# Altium schematic sheet Sheet1.SchDoc(Sheet1)
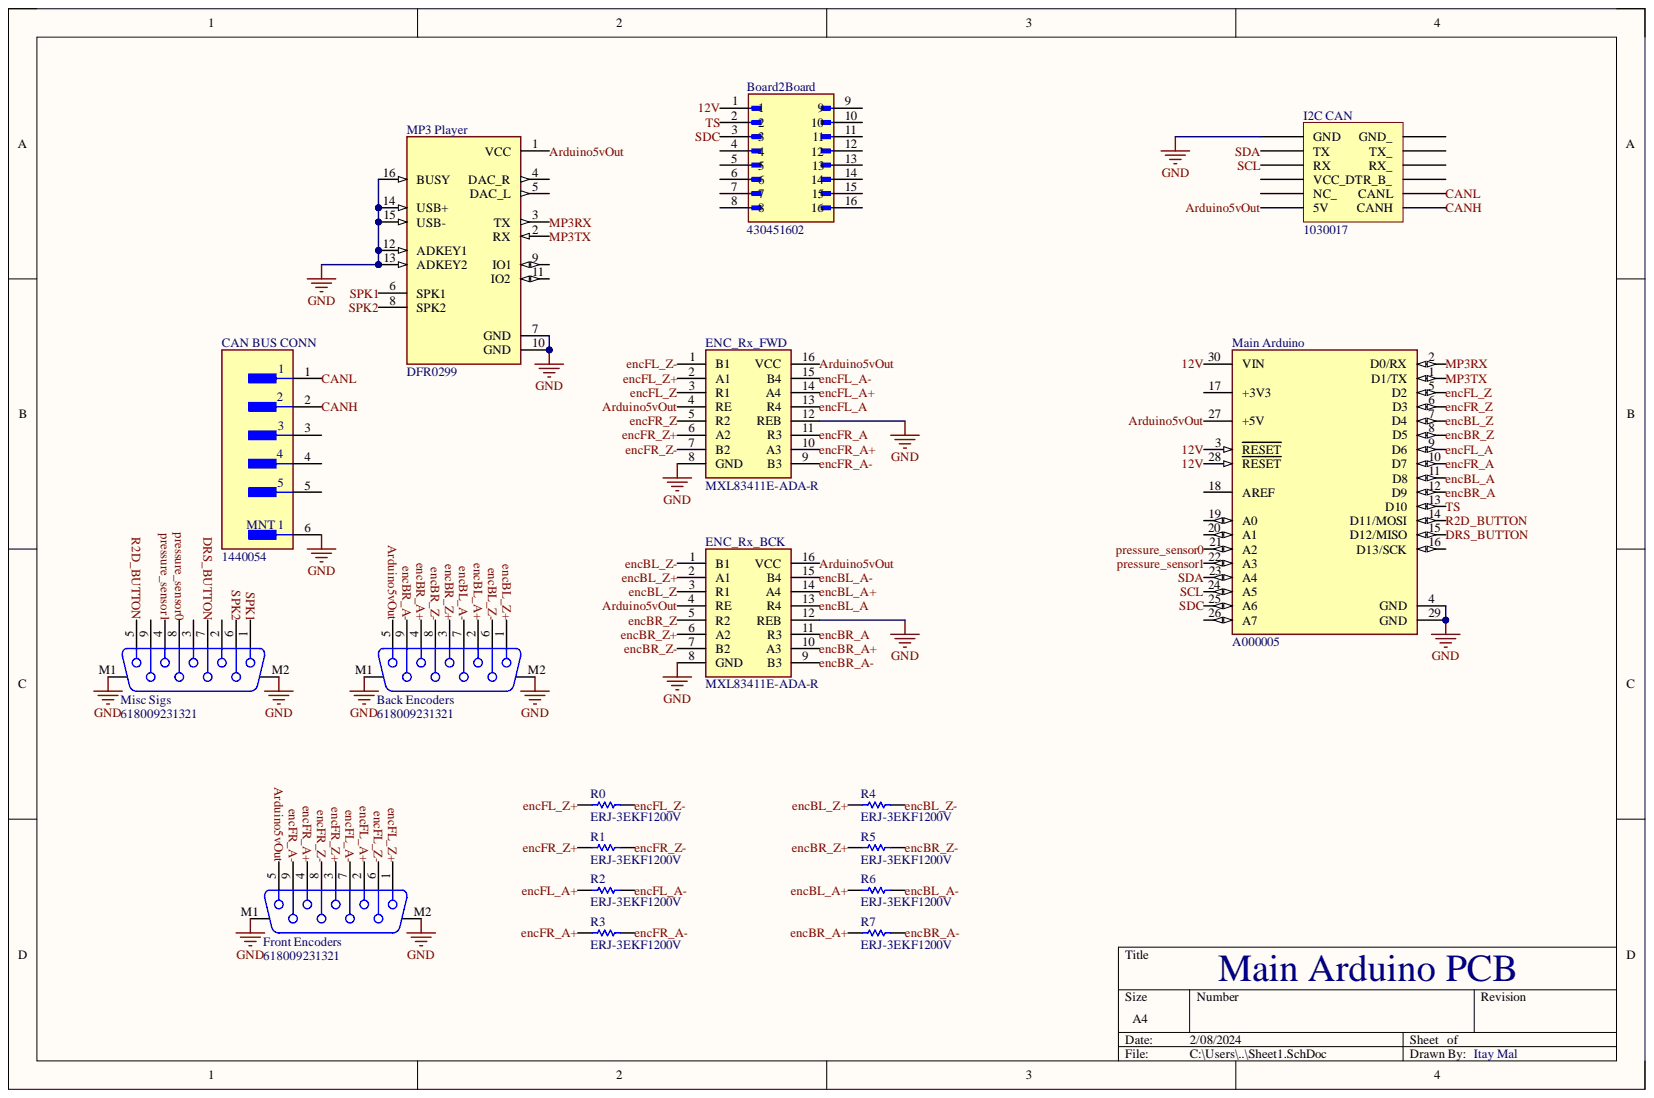
[diagram p0: Sheet1.SchDoc]

## Parts
Back_Encoders  618009231321  [618009231321]
Board2Board  430451602  [MOLEX_430451602]
CAN_BUS_CONN  1440054  [FP-1440054-MFG]
ENC_Rx_BCK  MXL83411E-ADA-R  [SOIC127P600X175-16N]
ENC_Rx_FWD  MXL83411E-ADA-R  [SOIC127P600X175-16N]
Front_Encoders  618009231321  [618009231321]
I2C_CAN  I2C_CAN  [I2C_CAN-Footprint-1]
Main_Arduino  A000005  Operating Supply Voltage: 12V  [FP-A000005-MFG]
Misc_Sigs  618009231321  [618009231321]
MP3_Player  DFR0299  [MODULE_DFR0299]
R0  ERJ-3EKF1200V  [RESC1609X55X30ML15T15]
R1  ERJ-3EKF1200V  [RESC1609X55X30ML15T15]
R2  ERJ-3EKF1200V  [RESC1609X55X30ML15T15]
R3  ERJ-3EKF1200V  [RESC1609X55X30ML15T15]
R4  ERJ-3EKF1200V  [RESC1609X55X30ML15T15]
R5  ERJ-3EKF1200V  [RESC1609X55X30ML15T15]
R6  ERJ-3EKF1200V  [RESC1609X55X30ML15T15]
R7  ERJ-3EKF1200V  [RESC1609X55X30ML15T15]

## Nets
GND: Back_Encoders.M1 Back_Encoders.M2 CAN_BUS_CONN.6 ENC_Rx_BCK.8(GND) ENC_Rx_BCK.12(REB) ENC_Rx_FWD.8(GND) ENC_Rx_FWD.12(REB) Front_Encoders.M1 Front_Encoders.M2 I2C_CAN.GND Main_Arduino.4(GND) Main_Arduino.29(GND) Misc_Sigs.M1 Misc_Sigs.M2 MP3_Player.7(GND) MP3_Player.10(GND) MP3_Player.12(ADKEY1) MP3_Player.13(ADKEY2) MP3_Player.14(USB+) MP3_Player.15(USB-) MP3_Player.16(BUSY)
NetI2C_CAN_DTR_B_: I2C_CAN.DTR_B_
NetI2C_CAN_GND_: I2C_CAN.GND_
NetI2C_CAN_NC_: I2C_CAN.NC_
NetI2C_CAN_RX_: I2C_CAN.RX_
NetI2C_CAN_TX_: I2C_CAN.TX_
NetI2C_CAN_VCC_: I2C_CAN.VCC_
12V: Board2Board.1 Main_Arduino.3(RESET) Main_Arduino.28(RESET) Main_Arduino.30(VIN)
Arduino5vOut: Back_Encoders.5 ENC_Rx_BCK.4(RE) ENC_Rx_BCK.16(VCC) ENC_Rx_FWD.4(RE) ENC_Rx_FWD.16(VCC) Front_Encoders.5 I2C_CAN.5V Main_Arduino.27(+5V) MP3_Player.1(VCC)
CANH: CAN_BUS_CONN.2 I2C_CAN.CANH
CANL: CAN_BUS_CONN.1 I2C_CAN.CANL
DRS_BUTTON: Main_Arduino.15(D12/MISO) Misc_Sigs.7
encBL_A: ENC_Rx_BCK.13(R4) Main_Arduino.11(D8)
encBL_A+: Back_Encoders.2 ENC_Rx_BCK.14(A4) R6.1
encBL_A-: Back_Encoders.7 ENC_Rx_BCK.15(B4) R6.2
encBL_Z: ENC_Rx_BCK.3(R1) Main_Arduino.7(D4)
encBL_Z+: Back_Encoders.1 ENC_Rx_BCK.2(A1) R4.1
encBL_Z-: Back_Encoders.6 ENC_Rx_BCK.1(B1) R4.2
encBR_A: ENC_Rx_BCK.11(R3) Main_Arduino.12(D9)
encBR_A+: Back_Encoders.4 ENC_Rx_BCK.10(A3) R7.1
encBR_A-: Back_Encoders.9 ENC_Rx_BCK.9(B3) R7.2
encBR_Z: ENC_Rx_BCK.5(R2) Main_Arduino.8(D5)
encBR_Z+: Back_Encoders.3 ENC_Rx_BCK.6(A2) R5.1
encBR_Z-: Back_Encoders.8 ENC_Rx_BCK.7(B2) R5.2
encFL_A: ENC_Rx_FWD.13(R4) Main_Arduino.9(D6)
encFL_A+: ENC_Rx_FWD.14(A4) Front_Encoders.2 R2.1
encFL_A-: ENC_Rx_FWD.15(B4) Front_Encoders.7 R2.2
encFL_Z: ENC_Rx_FWD.3(R1) Main_Arduino.5(D2)
encFL_Z+: ENC_Rx_FWD.2(A1) Front_Encoders.1 R0.1
encFL_Z-: ENC_Rx_FWD.1(B1) Front_Encoders.6 R0.2
encFR_A: ENC_Rx_FWD.11(R3) Main_Arduino.10(D7)
encFR_A+: ENC_Rx_FWD.10(A3) Front_Encoders.4 R3.1
encFR_A-: ENC_Rx_FWD.9(B3) Front_Encoders.9 R3.2
encFR_Z: ENC_Rx_FWD.5(R2) Main_Arduino.6(D3)
encFR_Z+: ENC_Rx_FWD.6(A2) Front_Encoders.3 R1.1
encFR_Z-: ENC_Rx_FWD.7(B2) Front_Encoders.8 R1.2
MP3RX: Main_Arduino.2(D0/RX) MP3_Player.3(TX)
MP3TX: Main_Arduino.1(D1/TX) MP3_Player.2(RX)
pressure_sensor0: Main_Arduino.21(A2) Misc_Sigs.8
pressure_sensor1: Main_Arduino.22(A3) Misc_Sigs.4
R2D_BUTTON: Main_Arduino.14(D11/MOSI) Misc_Sigs.5
SCL: I2C_CAN.RX Main_Arduino.24(A5)
SDA: I2C_CAN.TX Main_Arduino.23(A4)
SDC: Board2Board.3 Main_Arduino.25(A6)
SPK1: Misc_Sigs.1 MP3_Player.6(SPK1)
SPK2: Misc_Sigs.6 MP3_Player.8(SPK2)
TS: Board2Board.2 Main_Arduino.13(D10)

Not connected: Board2Board 4 5 6 7 8 9 10 11 12 13 14 15 16; CAN_BUS_CONN 3 4 5; Main_Arduino 16(D13/SCK) 17(+3V3) 18(AREF) 19(A0) 20(A1) 26(A7); Misc_Sigs 2 3 9; MP3_Player 4(DAC_R) 5(DAC_L) 9(IO1) 11(IO2)

## Library data of the parts
Back_Encoders Front_Encoders Misc_Sigs = CMP-000000-1: D Type Connector, 9 Contact(s), Female, 0.109 inch Pitch, Solder Terminal, Locking, Plug; Manufacturer Series: 6180; Body Breadth: 0.492inch; Body Depth: 0.581inch; Body Length: 1.213inch; Contact Pattern: STAGGERED; Contact Resistance: 30mR; Contact Style: RND PIN-SKT; Dielectric Withstanding Voltage (AC): 1kV; Insulation Resistance: 5GR; Mating Contact Row Spacing: 0.112inch; Number of Contacts: 9; Number Of PCB Rows: 2; PCB Contact Row Spacing: 2.8448mm; Rated Current (Signal): 3A; Reference Standard: UL; Reliability: COMMERCIAL; Body/Shell Style: PLUG; Component Kind: Standard; Contact Finish - Mating: AU; Contact Gender: Female; Contact Material: Copper Alloy; Insulation Color: Black; Insulation Material: Polybutylene; Library Name: main arduino pcb; Mating Contact Pitch: 0.109inch; Max Operating Temperature: 85°C; Min Operating Temperature: -40°C; Mounting Option 1: LOCKING; Mounting Style: RIGHT ANGLE; Mounting Technology: Board; Number of Rows Loaded: 2; PCB Contact Pattern: STAGGERED; Reach SVHC Compliant: Yes; RoHS Compliant: Yes; Shell Finish: Nickel; Shell Material: Steel; Terminal Length: 0.125inch; Terminal Pitch: 2.7686mm; Termination: Solder
Board2Board = CMP-005-000000-2: Availability: Unavailable; Component Kind: Standard; Component Type: Standard; Design Item ID: 430451602; Library Name: main arduino pcb; MP: 430451602; Package: None; Pin Count: 16; Price: None; Source: 430451602.SchLib
CAN_BUS_CONN = CMP-00002-1: Circular Connector; Component Kind: Standard; Connector Type: Circular; Contact Finish - Mating: NOT SPECIFIED; Library Name: main arduino pcb; Reach SVHC Compliant: No; RoHS Compliant: Yes
ENC_Rx_BCK ENC_Rx_FWD = MXL83411E-ADA-R: Integrated Circuit; Manufacturer_Name: MaxLinear; Component Kind: Standard; Datasheet Link: https://assets.maxlinear.com/web/documents/mxl83411.pdf; Height: 1.75mm; Manufacturer_Part_Number: MXL83411E-ADA-R; Mouser Part Number: 701-MXL83411E-ADA-R; Mouser Price/Stock: https://www.mouser.co.uk/ProductDetail/MaxLinear/MXL83411E-ADA-R?qs=Z%252BL2brAPG1KMxbLdzofcfg%3D%3D
I2C_CAN = CMP-007-000000-2: Component Kind: Standard; Component Type: Standard; Design Item ID: I2C_CAN; Library Name: main arduino pcb; Pin Count: 12; Source: Schlib1.SchLib
Main_Arduino = CMP-189986-000002-1: ARDUINO NANO ATMEGA328 EVAL BRD; Core Architecture: AVR; Data Bus Width: 8b; Evaluation Kit: Yes; Interface: I2C; Number of Bits: 8; Component Kind: Standard; Height: 0.25inch; Length: 7inch; Library Name: Altium Content Vault; Package Quantity: 50; Packaging: Bulk; REACH SVHC: No SVHC; Series: AVR® ATmega; Weight: 0.358383oz; Width: 5inch
MP3_Player = CMP-003-000000-2: Dfplayer - a Mini Mp3 Player; Availability: Unavailable; Component Kind: Standard; Component Type: Standard; Design Item ID: DFR0299; Library Name: main arduino pcb; MP: DFR0299; Package: None; Pin Count: 16; Price: None; Source: DFR0299.SchLib
R0-R7 = CMP-2000-00213-2: Chip Resistor, 120 Ohm, +/- 1%, 0.1 W, -55 to 155 degC, 0603 (1608 Metric), RoHS, Tape and Reel; Manufacturer: Panasonic; Max Power Dissipation: 100mW; Resistance: 120Ohm; Temperature Coefficient: 100ppm/degreesC; Voltage Rating: 75V; Case Code (Imperial): 0603; Case Code (Metric): 1608; Case/Package: 0603; Component Kind: Standard; Composition: ThickFilm; Contact Plating: Tin; Datasheet URL: https://industrial.panasonic.com/cdbs/www-data/pdf/RDA0000/AOA0000C283.pdf; Datasheet Version: 42095.0; Depth: 0.8mm; Height: 0.022inch; Lead Free: LeadFree; Length: 1.6mm; Library Name: Altium Content Vault; Manufacturer URL: http://www.panasonic.com/; Max Operating Temperature: 155degC; Military Standard: Not; Min Operating Temperature: -55degC; Mount: SurfaceMount; Number of Pins: 2; Number of Terminations: 2; Package Description: 2-Pin Surface Mount Device, Body 1.6 x 0.85 mm; Package Quantity: 1; Package Reference: 0603; Package URL: http://www.st.com/st-web-ui/static/active/en/resource/technical/document/datasheet/CD00001320.pdf; Packaging: TapeandReel; Power Rating: 100mW; Radiation Hardening: No; REACH SVHC: NoSVHC; RoHSCompliant: true; Termination: SMD/SMT; Tolerance: 1%; Voltage Rating (DC): 75V; Width: 0.031inch
